# Altium schematic sheet AFR_bar.SchDoc
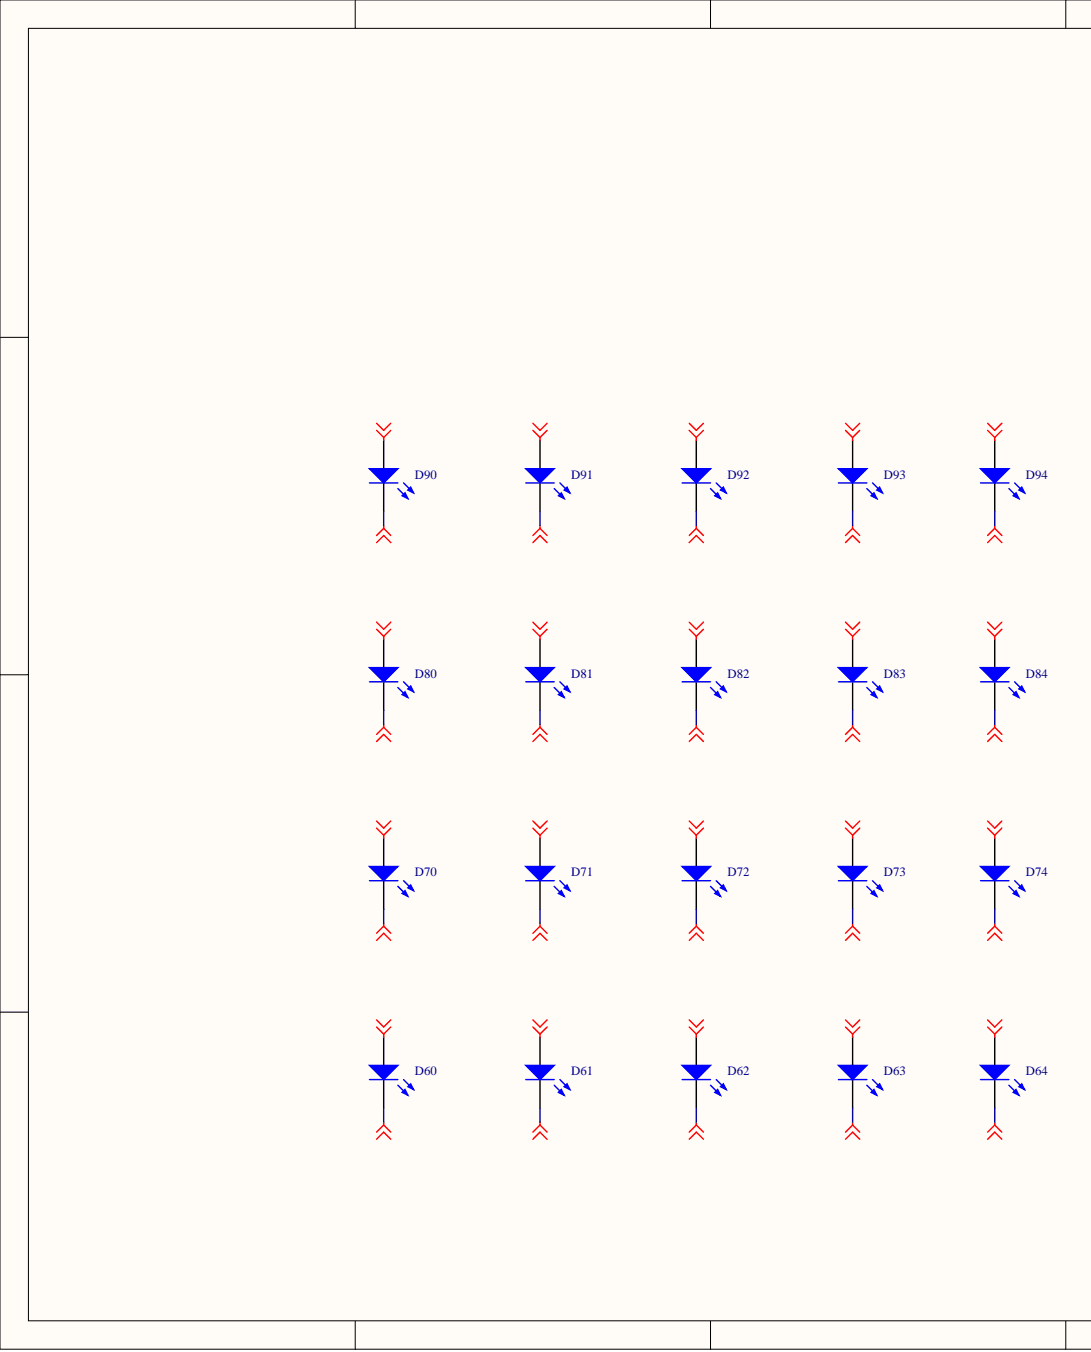
[diagram p0: AFR_bar.SchDoc, left]
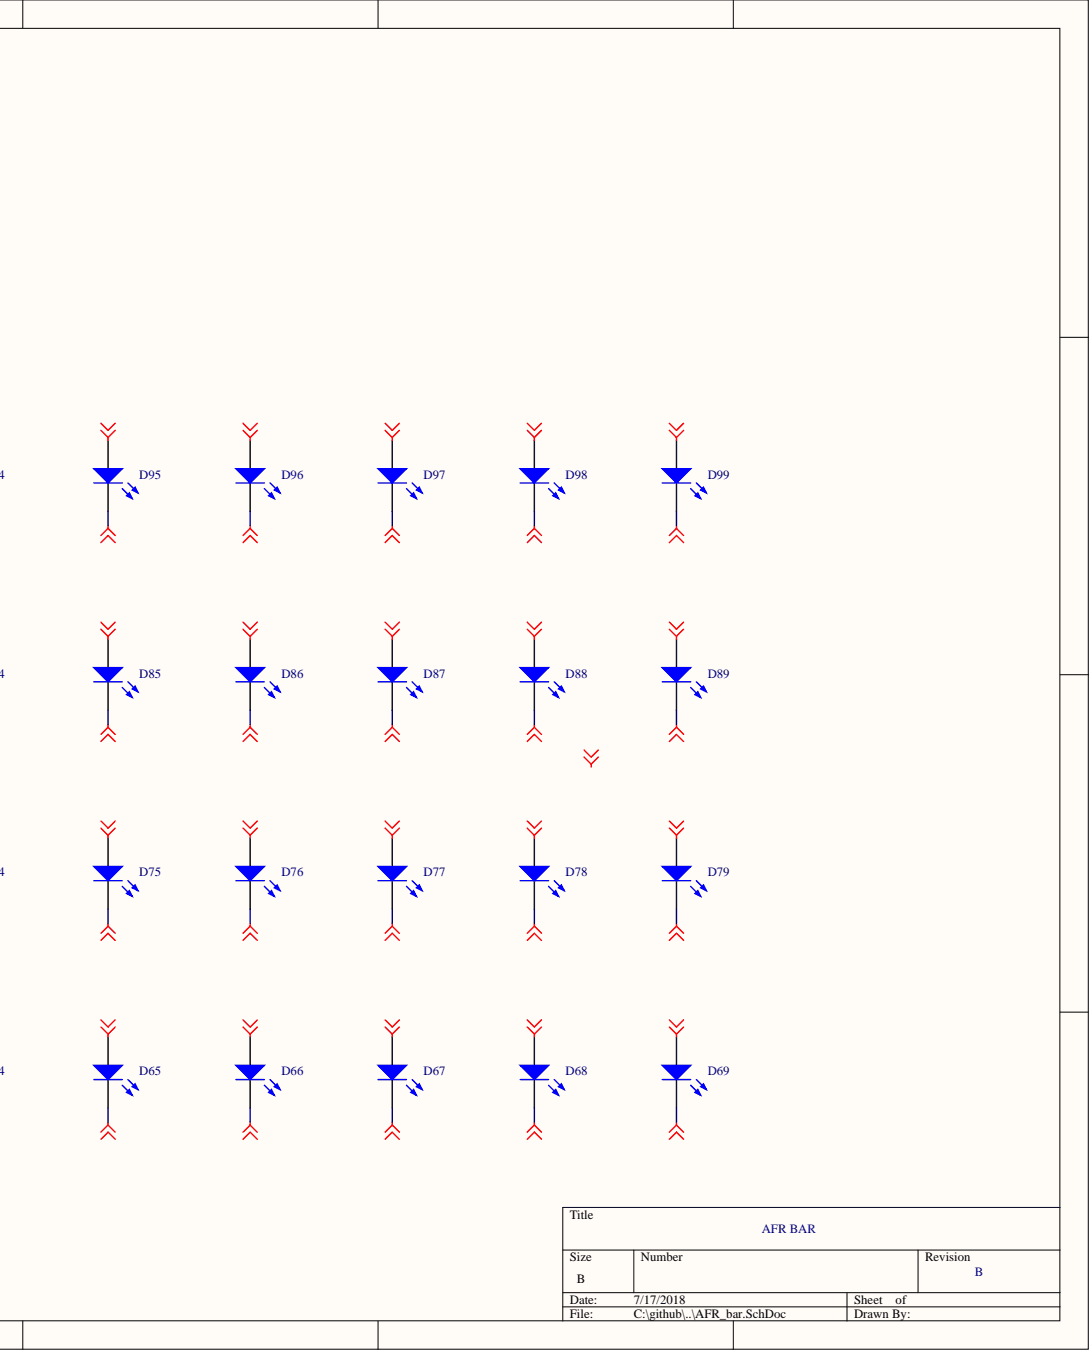
[diagram p1: AFR_bar.SchDoc, right]

## Parts
D60  (no value)  [DIODE_0603]
D61  (no value)  [DIODE_0603]
D62  (no value)  [DIODE_0603]
D63  (no value)  [DIODE_0603]
D64  (no value)  [DIODE_0603]
D65  (no value)  [DIODE_0603]
D66  (no value)  [DIODE_0603]
D67  (no value)  [DIODE_0603]
D68  (no value)  [DIODE_0603]
D69  (no value)  [DIODE_0603]
D70  (no value)  [DIODE_0603]
D71  (no value)  [DIODE_0603]
D72  (no value)  [DIODE_0603]
D73  (no value)  [DIODE_0603]
D74  (no value)  [DIODE_0603]
D75  (no value)  [DIODE_0603]
D76  (no value)  [DIODE_0603]
D77  (no value)  [DIODE_0603]
D78  (no value)  [DIODE_0603]
D79  (no value)  [DIODE_0603]
D80  (no value)  [DIODE_0603]
D81  (no value)  [DIODE_0603]
D82  (no value)  [DIODE_0603]
D83  (no value)  [DIODE_0603]
D84  (no value)  [DIODE_0603]
D85  (no value)  [DIODE_0603]
D86  (no value)  [DIODE_0603]
D87  (no value)  [DIODE_0603]
D88  (no value)  [DIODE_0603]
D89  (no value)  [DIODE_0603]
D90  (no value)  [DIODE_0603]
D91  (no value)  [DIODE_0603]
D92  (no value)  [DIODE_0603]
D93  (no value)  [DIODE_0603]
D94  (no value)  [DIODE_0603]
D95  (no value)  [DIODE_0603]
D96  (no value)  [DIODE_0603]
D97  (no value)  [DIODE_0603]
D98  (no value)  [DIODE_0603]
D99  (no value)  [DIODE_0603]

## Nets
D5: D60.1 D61.1 D62.1 D63.1 D64.1 D65.1 D66.1 D67.1 D68.1 D69.1
D6: D70.1 D71.1 D72.1 D73.1 D74.1 D75.1 D76.1 D77.1 D78.1 D79.1
D7: D80.1 D81.1 D82.1 D83.1 D84.1 D85.1 D86.1 D87.1 D88.1 D89.1
D8: D90.1 D91.1 D92.1 D93.1 D94.1 D95.1 D96.1 D97.1 D98.1 D99.1
G0/IO_VB3N2_69: D60.2 D70.2 D80.2 D90.2
G1/IO_VB3N2_70: D61.2 D71.2 D81.2 D91.2
G2/IO_VB3N1_78: D62.2 D72.2 D82.2 D92.2
G3/IO_VB3N1_80: D63.2 D73.2 D83.2 D93.2
G4/IO_VB3N1_81: D64.2 D74.2 D84.2 D94.2
G5/IO_VB3N1_82: D65.2 D75.2 D85.2 D95.2
G6/IO_VB3N1_83: D66.2 D76.2 D86.2 D96.2
G7/IO_VB3N0_87: D67.2 D77.2 D87.2 D97.2
G8/IO_VB3N0_88: D68.2 D78.2 D88.2 D98.2
G9/IO_VB4N3_93: D69.2 D79.2 D89.2 D99.2

## Library data of the parts
D60-D99 = LED0: Typical INFRARED GaAs LED; Component Kind: Standard; LatestRevisionDate: 08-Jul-2005; LatestRevisionNote: Mech Layer 1 removed.; Library Name: Miscellaneous Devices.IntLib; PackageDescription: LED; 2 Leads; PackageReference: LED-0; Published: 8-Jun-2000; Simulation: LED0; Publisher: Altium Limited
